# Altium schematic sheet Peripherals - USB.SchDoc("Peripherals - USB")
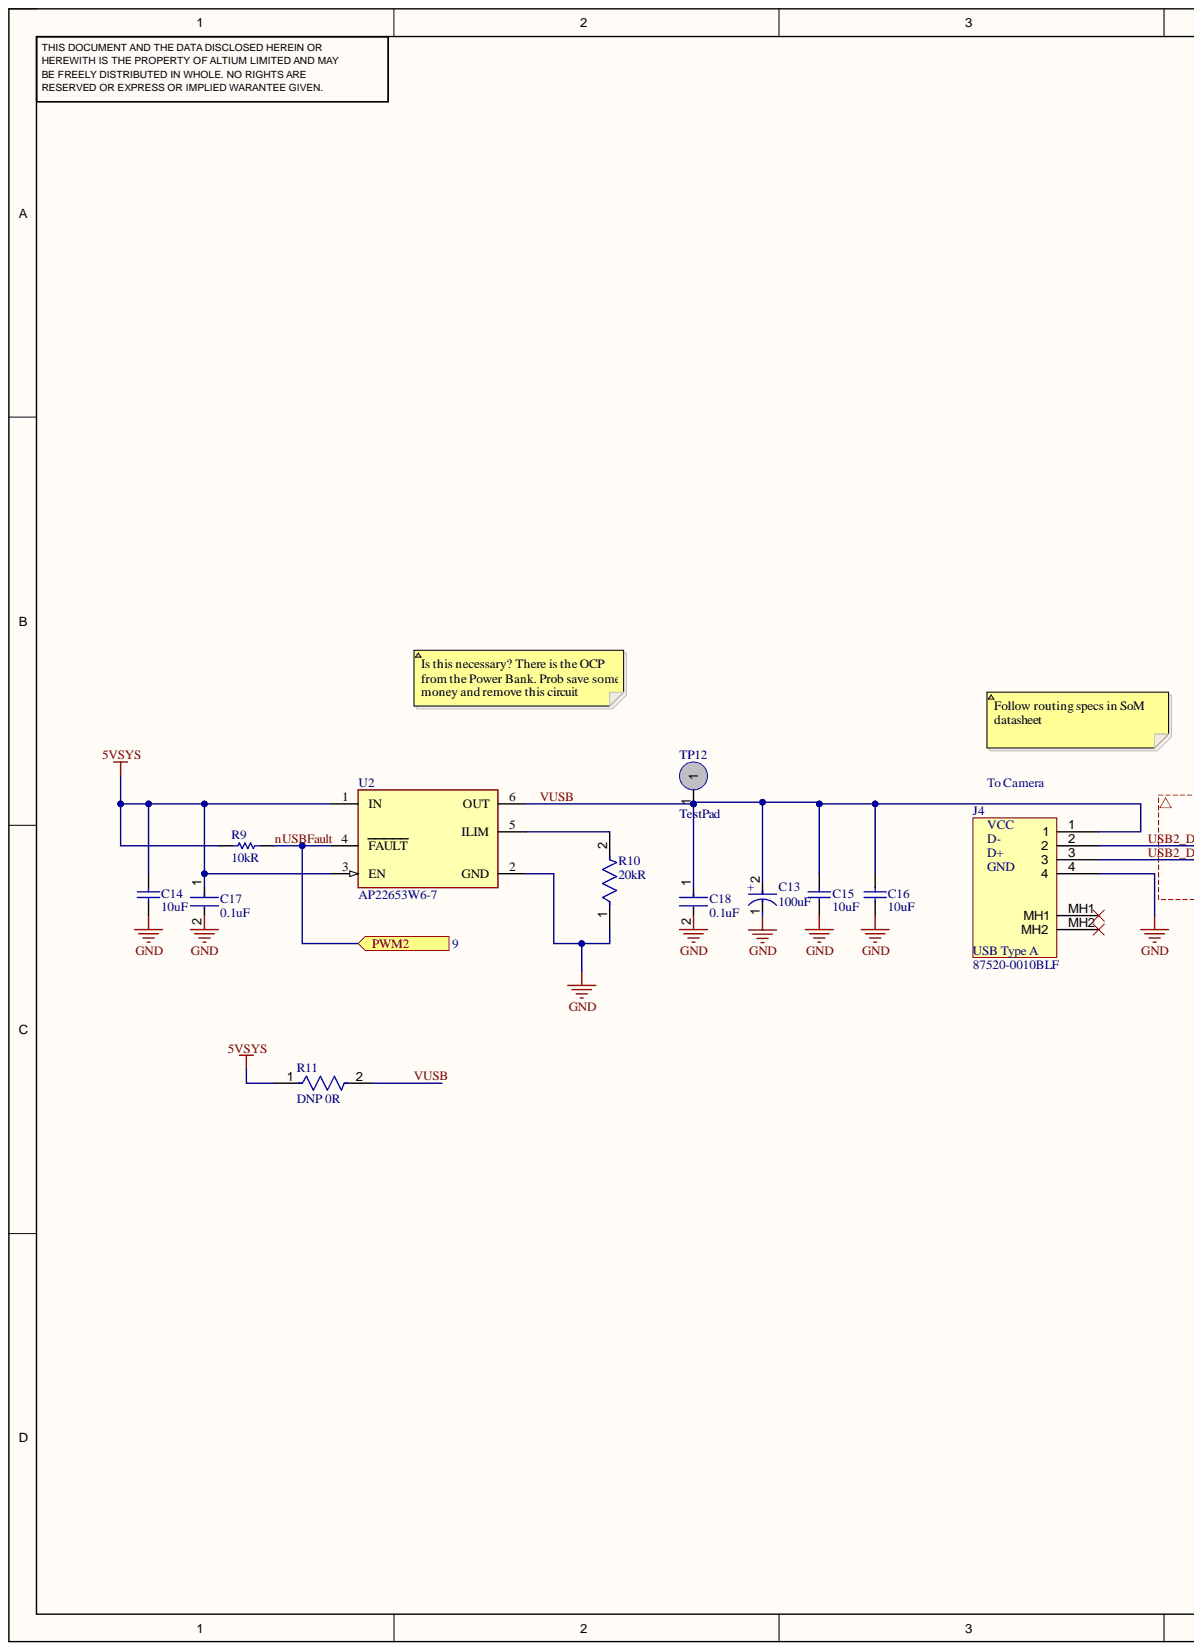
[diagram p0: Peripherals - USB.SchDoc, left]
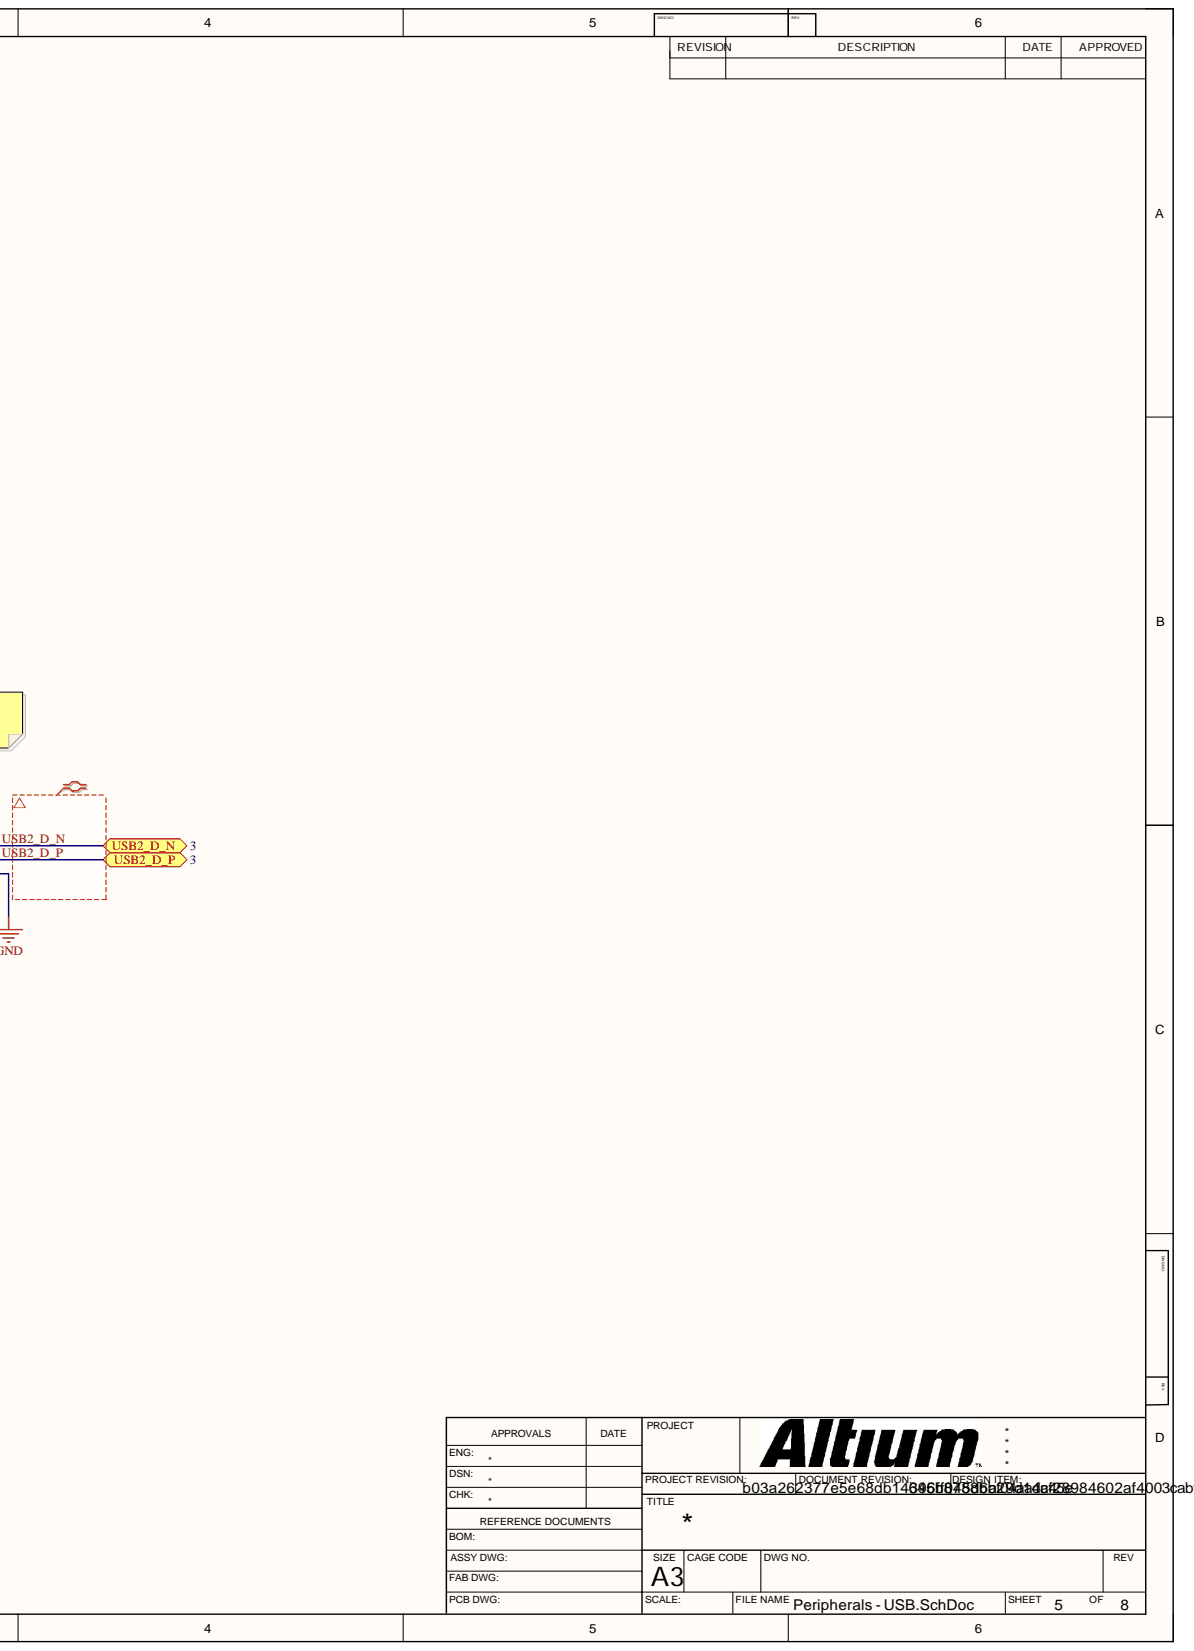
[diagram p1: Peripherals - USB.SchDoc, right]

## Parts
C13  100uF  [FP-UWX1E101MCL1GB-MFG]
C14  10uF  [CAPC2013X145X20ML20]
C15  10uF  [CAPC2013X145X20ML20]
C16  10uF  [CAPC2013X145X20ML20]
C17  0.1uF  [FP-C0603-CJ-MFG]
C18  0.1uF  [FP-C0603-CJ-MFG]
J4  87520-0010BLF  [875200010BLF]
R9  10kR  [RESC2013X60X35NL10T20]
R10  20kR  [FP-CRCW0805-e3-MFG]
R11  DNP 0R  [FP-RC1206-MFG]
TP12  TestPad  [TestPad50mil]
U2  AP22653W6-7  [FP-SOT26-MFG]

## Ports
PWM2, USB2_D_N, USB2_D_P

## Nets
5VSYS: C14.2 C17.1 R9.2 R11.1 U2.1(IN) U2.3(EN)
GND: C13.1 C14.1 C15.1 C16.1 C17.2 C18.2 J4.4 R10.1 U2.2(GND)
nUSBFault: R9.1 U2.4(FAULT) ; port PWM2
USB2_D_N: J4.2 ; port USB2_D_N
USB2_D_P: J4.3 ; port USB2_D_P
VUSB: C13.2 C15.2 C16.2 C18.1 J4.1 R11.2 TP12.1 U2.6(OUT)
NetR10_2: R10.2 U2.5(ILIM)

Not connected: J4 MH1 MH2

## Library data of the parts
C13 = CMP-12462-000012-1: UWX1E101MCL1GB  CAP ALUM 100UF 20% 25V SMD; Dielectric Material: Aluminium; Dissipation Factor: 0.14%; Lead Diameter: 0.8mm; Leakage Current: 3uA; Life (Hours): 2000Hour; Polarity: Polar; Ripple Current: 110mA; Capacitance: 100uF; Case/Package: Radial; Component Kind: Standard; Diameter: 8mm; Height: 5.4mm; Height - Seated (Max): 0.213inch; Lead Free: Lead Free; Lead Pitch: 2.3mm; Length: 5.4mm; Library Name: Altium Content Vault; Max Operating Temperature: 85°C; Min Operating Temperature: -40°C; Mount: Surface Mount; Number of Pins: 2; Package Quantity: 1000; Packaging: Tape and Reel; Radiation Hardening: No; REACH SVHC: No SVHC; Ripple Current (AC): 110mA; Termination: SMD/SMT; Tolerance: 20%; Voltage: 25V; Voltage Rating: 25V; Voltage Rating (DC): 25V; Weight: 0.01lb; Width: 0.25inch
C14-C16 = CMP-2000-05452-1: C2012X7R1A106K125AC Multilayer Ceramic Chip Capacitor, 10 uF, +/- 10%, 10 V, -55 to 125 degC, 0805 (2012 Metric), RoHS, Tape and Reel; Manufacturer: TDK; PCN Part Number: MLCCPartNumberChange30/Nov/2012; Capacitance: 10µF; Online Catalog: CSeries,GeneralPurpose; Other Names: 445-6857-6; Product Training Modules: CSeriesGeneralApplicationsSEAT,CCV,andTVCLDesignToolsGuidetoReplacinganElectrolyticCapacitorwithanMLCC; Temperature Coefficient: X7R; Voltage - Rated: 10V; Applications: GeneralPurpose; Category: Capacitors; Component Kind: Standard; Datasheet URL: http://download.siliconexpert.com/pdfs/2015/4/21/15/54/45/779/tdk_/manual/37024850441143360mlcc_commercial_general_en.pdf; Datasheet Version: Mar-15; Family: CeramicCapacitors; Features: -; Height - Seated (Max): -; Lead Spacing: -; Lead Style: -; Library Name: Altium Content Vault; Manufacturer URL: http://www.tdk.com/; Mounting Type: SurfaceMount,MLCC; Operating Temperature: -55degC~125degC; Package / Case: 0805(2012Metric); Package Description: 2-Pin Surface Mount Device, Body 2 x 1.25 mm; Package Reference: 805; Packaging: Digi-Reel; Ratings: -; RoHS Information: RoHSCertificate-MLCC; Series: C; Size / Dimension: 0.079"Lx0.049"W(2.00mmx1.25mm); Standard Package: 1; Thickness (Max): 0.057"(1.45mm); Tolerance: ±10%
C17 C18 = CMP-2006-03300-2: C0603X104K5RACTU CAP CER 0.1UF 50V X7R 0603; Manufacturer: Kemet; Capacitance: 100nF; Material: Ceramic; Temperature Coefficient: 15%; Tolerance: 10%; Value: 100nF; Automotive: No; Category: Cap; Component Kind: Standard; ComponentLink2Description: Datasheet; ComponentLink2URL: https://content.kemet.com/datasheets/KEM_C1013_X7R_FT-CAP_SMD.pdf; Device Class L1: Passive Components; Device Class L2: Capacitors; Device Class L3: Ceramic Capacitors; Digikey Description: CAP CER 0.1UF 50V X7R 0603; Height: 0.95mm; Ipc Land Pattern Name: CAPC16080X80; Lead Free: Yes; Library Name: Altium Content Vault; Manufacturer Part Number: C0603X104K5RACTU; Mouser Description: Capacitor; Ceramic; Cap 0.1uF; Tol+10%; SMT; Flexible Termination; Vol-Rtg 50V; TR; Octopart: https://octopart.com/c0603x104k5ractu-kemet-9145062; Package: C0603-CJ; RoHS: TRUE; Temperature: -55°C to +125°C; Temperature Characteristic: X7R; Temperature Range High: +125°C; Temperature Range Low: -55°C; Voltage: 50V; Voltage Rating: 50V
R9 = CMP-1659-00013-4: RC0805FR-0710KL Chip Resistor, 10 KOhm, +/- 1%, 0.125 W, -55 to 155 degC, 0805 (2012 Metric), RoHS, Tape and Reel; Manufacturer: Yageo; Voltage Rating: 150 V; Component Kind: Standard; ComponentLink1Description: Manufacturer URL; ComponentLink1URL: http://www.yageo.com/; ComponentLink2Description: Datasheet; ComponentLink2URL: http://www.yageo.com/documents/recent/PYu-RC_Group_51_RoHS_L_04.pdf; DatasheetVersion: Rev. 4, 02/2016; Height: 0.5 mm; Length: 2 mm; Library Name: Altium Content Vault; Max Operating Temperature: 155 degC; Min Operating Temperature: -55 degC; Mount: Surface Mount; Mounting Technology: Surface Mount; Package Quantity: 5000; PackageDescription: 2-Pin Surface Mounted Device, Body 2 x 1.25 mm; PackageReference: 0805; Packaging: Tape and Reel; Pins: 2; REACH SVHC: No SVHC; RoHSCompliant: true
R10 = CMP-2001-03667-2: RES Thick Film, 20kΩ, 1%, 0.125W, 100ppm/°C, 0805; Max Power Dissipation: 125mW; Voltage Rating: 150V; Case Code (Imperial): 0805; Case Code (Metric): 2012; Case/Package: 0805; Component Kind: Standard; Composition: Thick Film; Contact Plating: Tin; Depth: 1.25mm; Features: Automotive AEC-Q200; Height: 0.45mm; Lead Free: Lead Free; Length: 2mm; Library Name: Altium Content Vault; Max Operating Temperature: 155°C; Military Standard: Not; Min Operating Temperature: -55°C; Mount: Surface Mount; Number of Pins: 2; Number of Terminations: 2; Package Quantity: 10; Packaging: Tape and Reel; Power Rating: 125mW; Resistance: 20kR; Temperature Coefficient: 100ppm/°C; Termination: SMD/SMT; Tolerance: 1%; Voltage Rating (DC): 150V; Weight: 0.000194oz; Width: 1.25mm; Working Voltage: 150V
R11 = CMP-2100-03681-2: RC1206JR-070RL RES SMD 0 OHM JUMPER 1/4W 1206; Manufacturer: YAGEO; Power Rating: 0.25W; Resistance: 0Ω; Temperature Coefficient: 300ppm/°C; Tolerance: 5%; Value: 0Ω; Voltage Rating: 200V; Automotive: No; Category: Res; Component Kind: Standard; ComponentLink2Description: Datasheet; ComponentLink2URL: https://www.yageo.com/upload/media/product/productsearch/datasheet/rchip/PYu-RC_Group_51_RoHS_L_10.pdf; Composition: Thick Film; Device Class L1: Passive Components; Device Class L2: Resistors; Device Class L3: Chip SMD Resistors; Digikey Description: RES SMD 0 OHM JUMPER 1/4W 1206; Footprint Url: https://www.yageo.com/upload/website/yageo_PYu-R_Mount_10_19050818_343.pdf; Height: 0.65mm; Ipc Land Pattern Name: RESC310160X55; Lead Free: Yes; Library Name: Altium Content Vault; Manufacturer Part Number: RC1206JR-070RL; Mouser Description: Resistor: thick film; SMD; 1206; 0O; 0.25W; ±5%; -55÷155°C; Octopart: https://octopart.com/rc1206jr-070rl-yageo-40025601; Package: RC1206; RoHS: TRUE; Series: RC; Temperature: -55°C to +155°C; Temperature Range High: +155°C; Temperature Range Low: -55°C
U2 = CMP-12749-000002-1: LOAD SWITCH,SOT26,T&R,3K; Component Kind: Standard; Library Name: Altium Content Vault
J4 = 87520-0010BLF: Component Kind: Standard; Library Name: SchemSymbolsGoogle.SchLib
TP12 = TestPad: Component Kind: Standard; Library Name: SchemSymbolsGoogle.SchLib
